# Altium schematic sheet For DEBUG.SchDoc("For DEBUG")
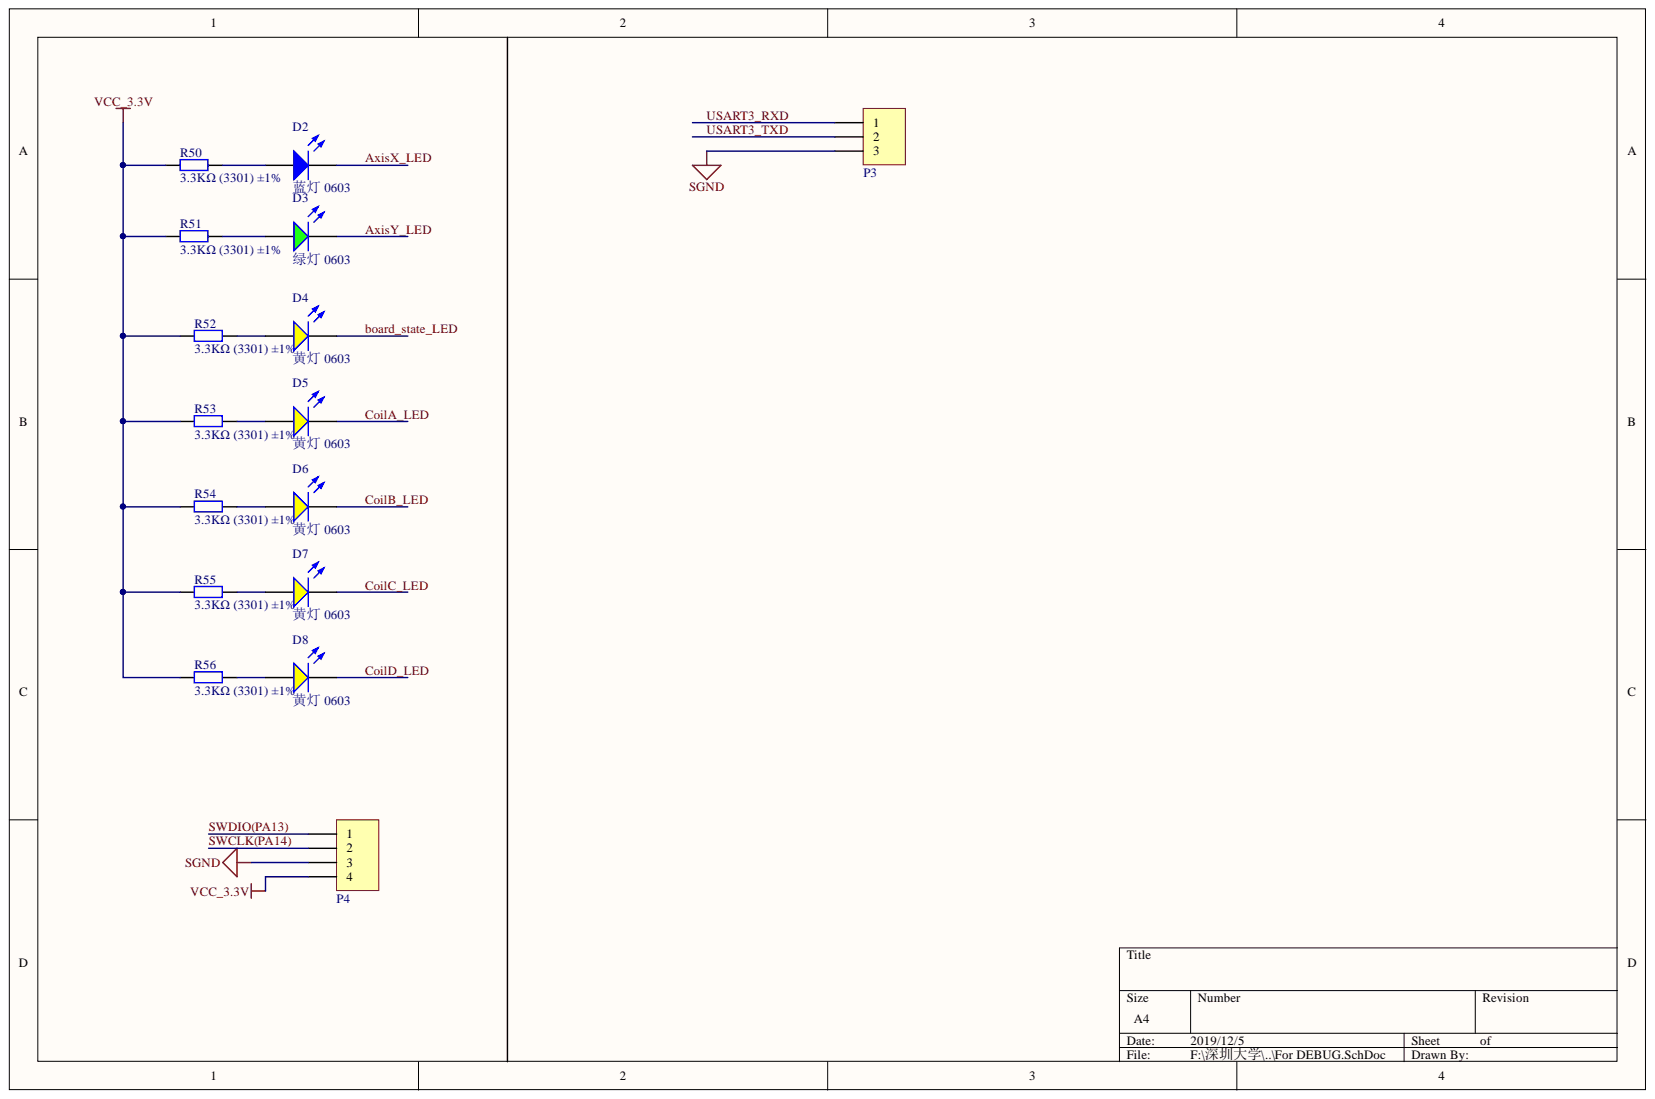
[diagram p0: For DEBUG.SchDoc]

## Parts
D2  蓝灯 0603  Package: 0603  [0603_LED_S1]
D3  绿灯 0603  Package: 0603  [0603_LED_S1]
D4  黄灯 0603  Package: 0603  [0603_LED_S1]
D5  黄灯 0603  Package: 0603  [0603_LED_S1]
D6  黄灯 0603  Package: 0603  [0603_LED_S1]
D7  黄灯 0603  Package: 0603  [0603_LED_S1]
D8  黄灯 0603  Package: 0603  [0603_LED_S1]
P3  Header 3  [HDR3X1_B1]  (value not drawn)
P4  Header 4  [HDR4X1_B1]  (value not drawn)
R50  3.3KΩ (3301) ±1%  [0603_R]
R51  3.3KΩ (3301) ±1%  [0603_R]
R52  3.3KΩ (3301) ±1%  [0603_R]
R53  3.3KΩ (3301) ±1%  [0603_R]
R54  3.3KΩ (3301) ±1%  [0603_R]
R55  3.3KΩ (3301) ±1%  [0603_R]
R56  3.3KΩ (3301) ±1%  [0603_R]

## Nets
SGND: P3.3 P4.3
VCC_3.3V: P4.4 R50.1 R51.1 R52.1 R53.1 R54.1 R55.1 R56.1
AxisX_LED: D2.K
AxisY_LED: D3.K
board_state_LED: D4.K
CoilA_LED: D5.K
CoilB_LED: D6.K
CoilC_LED: D7.K
CoilD_LED: D8.K
SWCLK(PA14): P4.2
SWDIO(PA13): P4.1
USART3_RXD: P3.1
USART3_TXD: P3.2
NetD2_A: D2.A R50.2
NetD3_A: D3.A R51.2
NetD4_A: D4.A R52.2
NetD5_A: D5.A R53.2
NetD6_A: D6.A R54.2
NetD7_A: D7.A R55.2
NetD8_A: D8.A R56.2

## Library data of the parts
D2 = 0603 蓝灯: 发光二极管; SuppliersPartNumber: C72041; Supplier: LC; Library Name: JLC Library.IntLib; ComponentLink1URL: http://www.szlcsc.com/product/details_73150.html; ComponentLink1Description: 供应商链接; Component Kind: Standard
D3 = 0603 绿灯: 发光二极管; SuppliersPartNumber: C72043; Supplier: LC; Library Name: JLC Library.IntLib; ComponentLink1URL: http://www.szlcsc.com/product/details_73152.html; ComponentLink1Description: 供应商链接; Component Kind: Standard
D4-D8 = 0603 黄灯: 发光二极管; SuppliersPartNumber: C72038; Supplier: LC; Library Name: JLC Library.IntLib; ComponentLink1URL: http://www.szlcsc.com/product/details_73147.html; ComponentLink1Description: 供应商链接; Component Kind: Standard
P3 = Header 3: 接插件; LatestRevisionNote: Re-released for DXP Platform.; LatestRevisionDate: 17-Jul-2002; Component Kind: Standard; Publisher: Altium Limited; Library Name: 接插件集成库.IntLib
P4 = Header 4: 接插件; LatestRevisionNote: Re-released for DXP Platform.; LatestRevisionDate: 17-Jul-2002; Component Kind: Standard; Publisher: Altium Limited; Library Name: 接插件集成库.IntLib
R50-R56 = 0603 3.3KΩ (3301) 1%: 贴片电阻; SuppliersPartNumber: C22978; Supplier: LC; Package: 0603; Library Name: JLC Library.IntLib; ComponentLink1URL: http://www.szlcsc.com/product/details_23705.html; ComponentLink1Description: 供应商链接; Component Kind: Standard
